# Altium schematic sheet JacdacHub 39.SchDoc
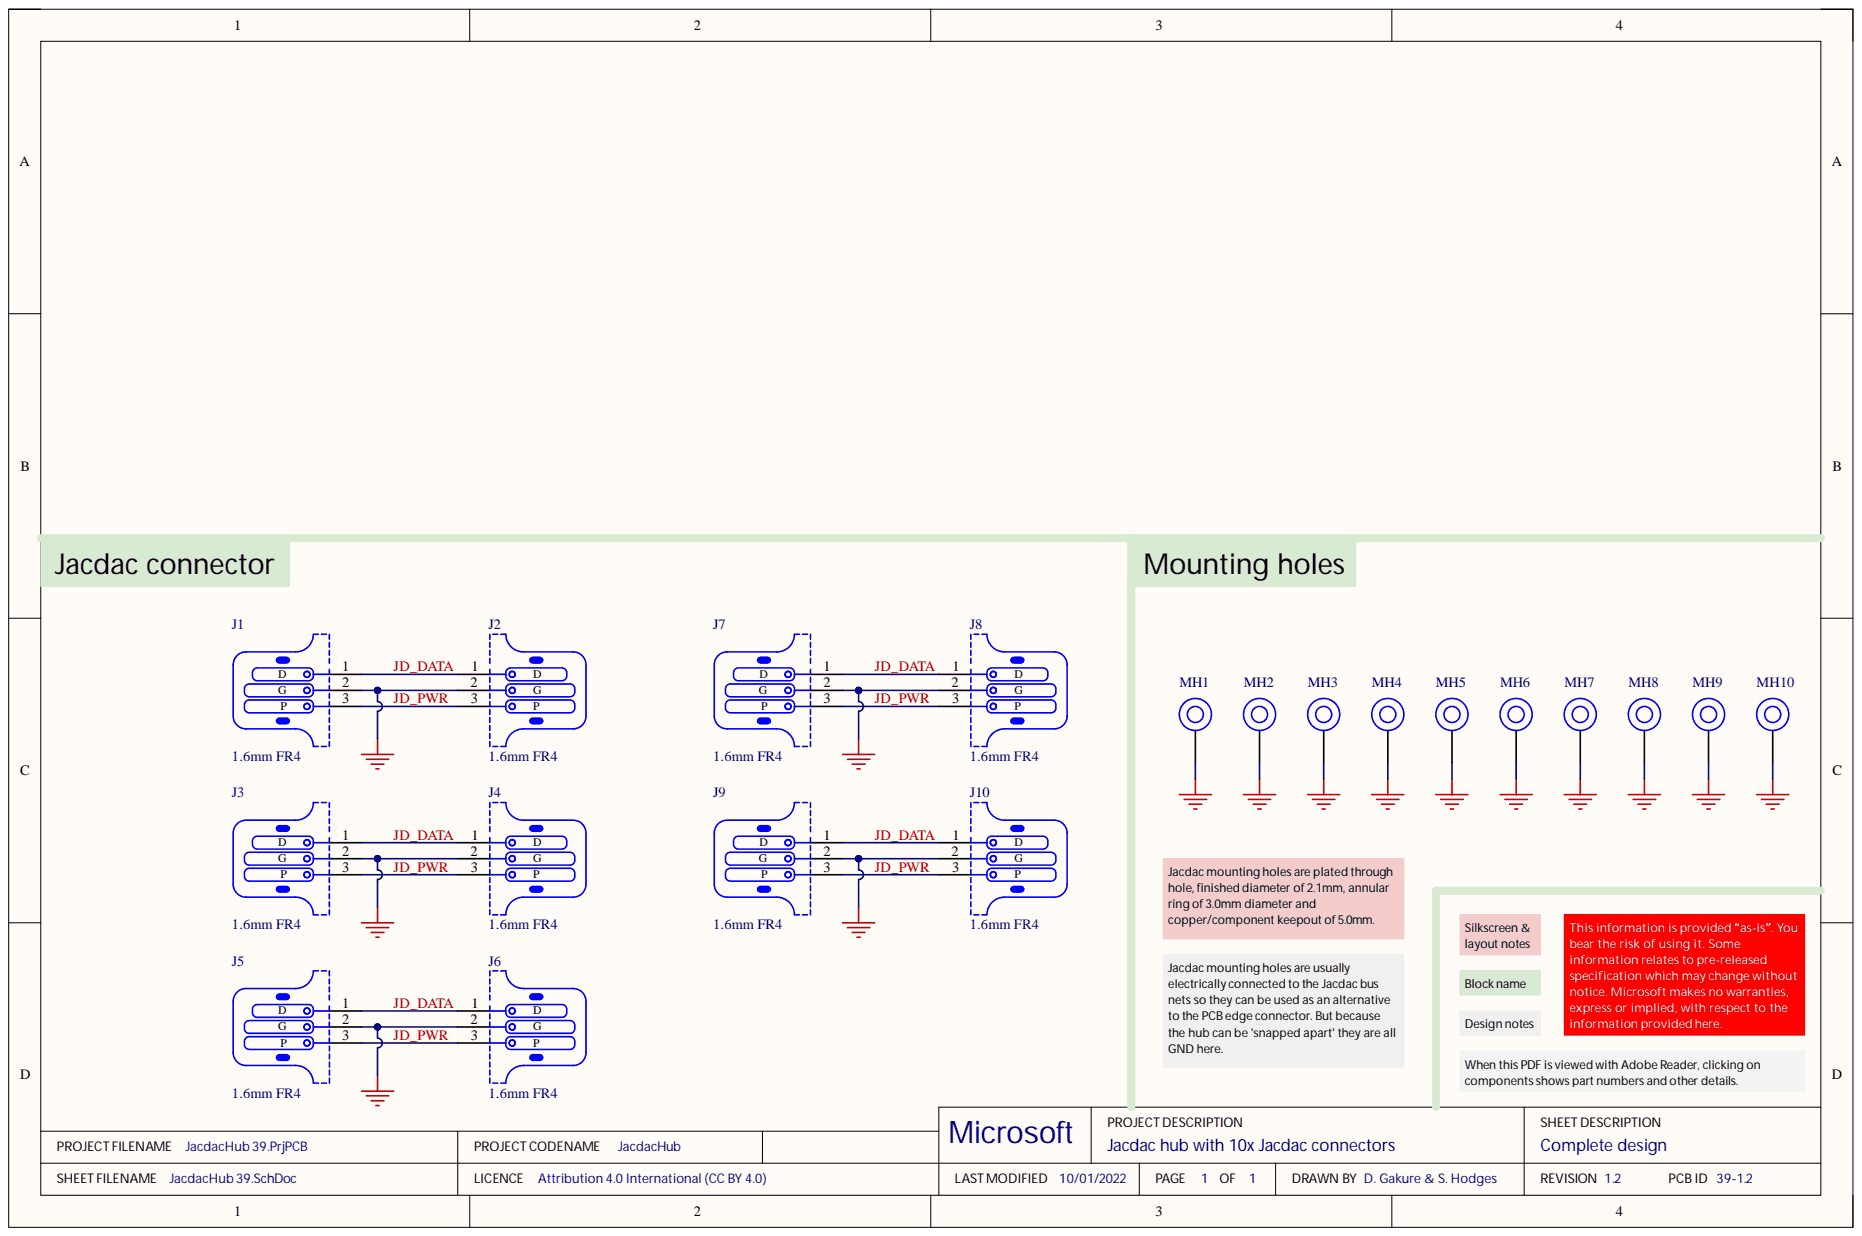
[diagram p0: JacdacHub 39.SchDoc]

## Parts
J1  PCB Type: 1.6mm FR4
J2  PCB Type: 1.6mm FR4
J3  PCB Type: 1.6mm FR4
J4  PCB Type: 1.6mm FR4
J5  PCB Type: 1.6mm FR4
J6  PCB Type: 1.6mm FR4
J7  PCB Type: 1.6mm FR4
J8  PCB Type: 1.6mm FR4
J9  PCB Type: 1.6mm FR4
J10  PCB Type: 1.6mm FR4
MH1  (no value)
MH2  (no value)
MH3  (no value)
MH4  (no value)
MH5  (no value)
MH6  (no value)
MH7  (no value)
MH8  (no value)
MH9  (no value)
MH10  (no value)

## Nets
GND: J1.2 J2.2 J3.2 J4.2 J5.2 J6.2 J7.2 J8.2 J9.2 J10.2 MH1.1 MH2.1 MH3.1 MH4.1 MH5.1 MH6.1 MH7.1 MH8.1 MH9.1 MH10.1
JD_DATA: J1.1 J2.1 J3.1 J4.1 J5.1 J6.1 J7.1 J8.1 J9.1 J10.1
JD_PWR: J1.3 J2.3 J3.3 J4.3 J5.3 J6.3 J7.3 J8.3 J9.3 J10.3

## Library data of the parts
J1-J10 = (no symbol name): 3 pin Jacdac edge connector 1.27mm pitch; Manufacturer Part Number: JACDAC_EDGE_CONNECTOR; ComponentLink1Description: Yuliang JACDAC cable connector drawing online; ComponentLink1URL: https://github.com/microsoft/jacdac-mdk/blob/main/connector/JACDAC-Connector-by-Yuliang-2020-11-28.pdf; ComponentLink2Description: JACDAC PCB edge connector spec onlin; ComponentLink2URL: https://github.com/microsoft/jacdac-mdk/blob/main/connector/JACDAC_PCB_Edge_Connector_Drawing_JD-PEC-01_rev_01.pdf
MH1-MH10 = (no symbol name): Mounting Hole 5.0mm keepout, 3.0mm annualar ring and 2.1mm Drill PTH
